# Altium schematic sheet Power.SchDoc(Power)
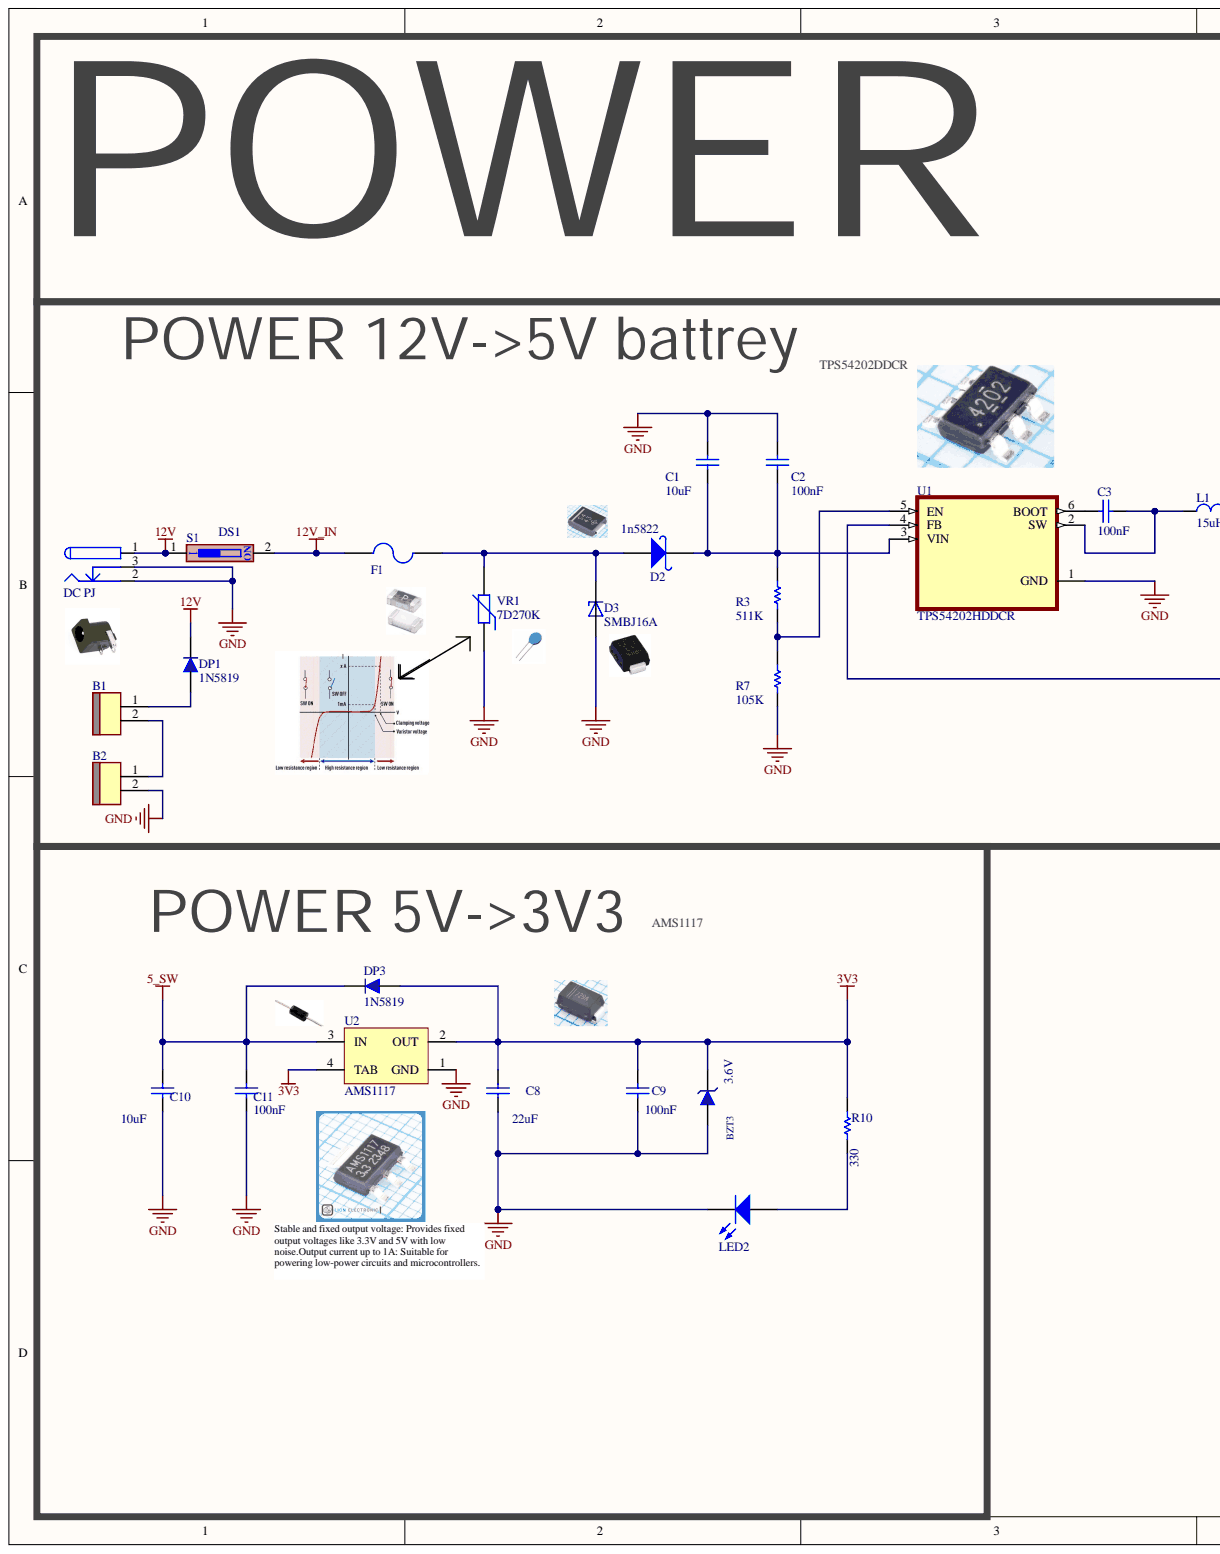
[diagram p0: Power.SchDoc, left]
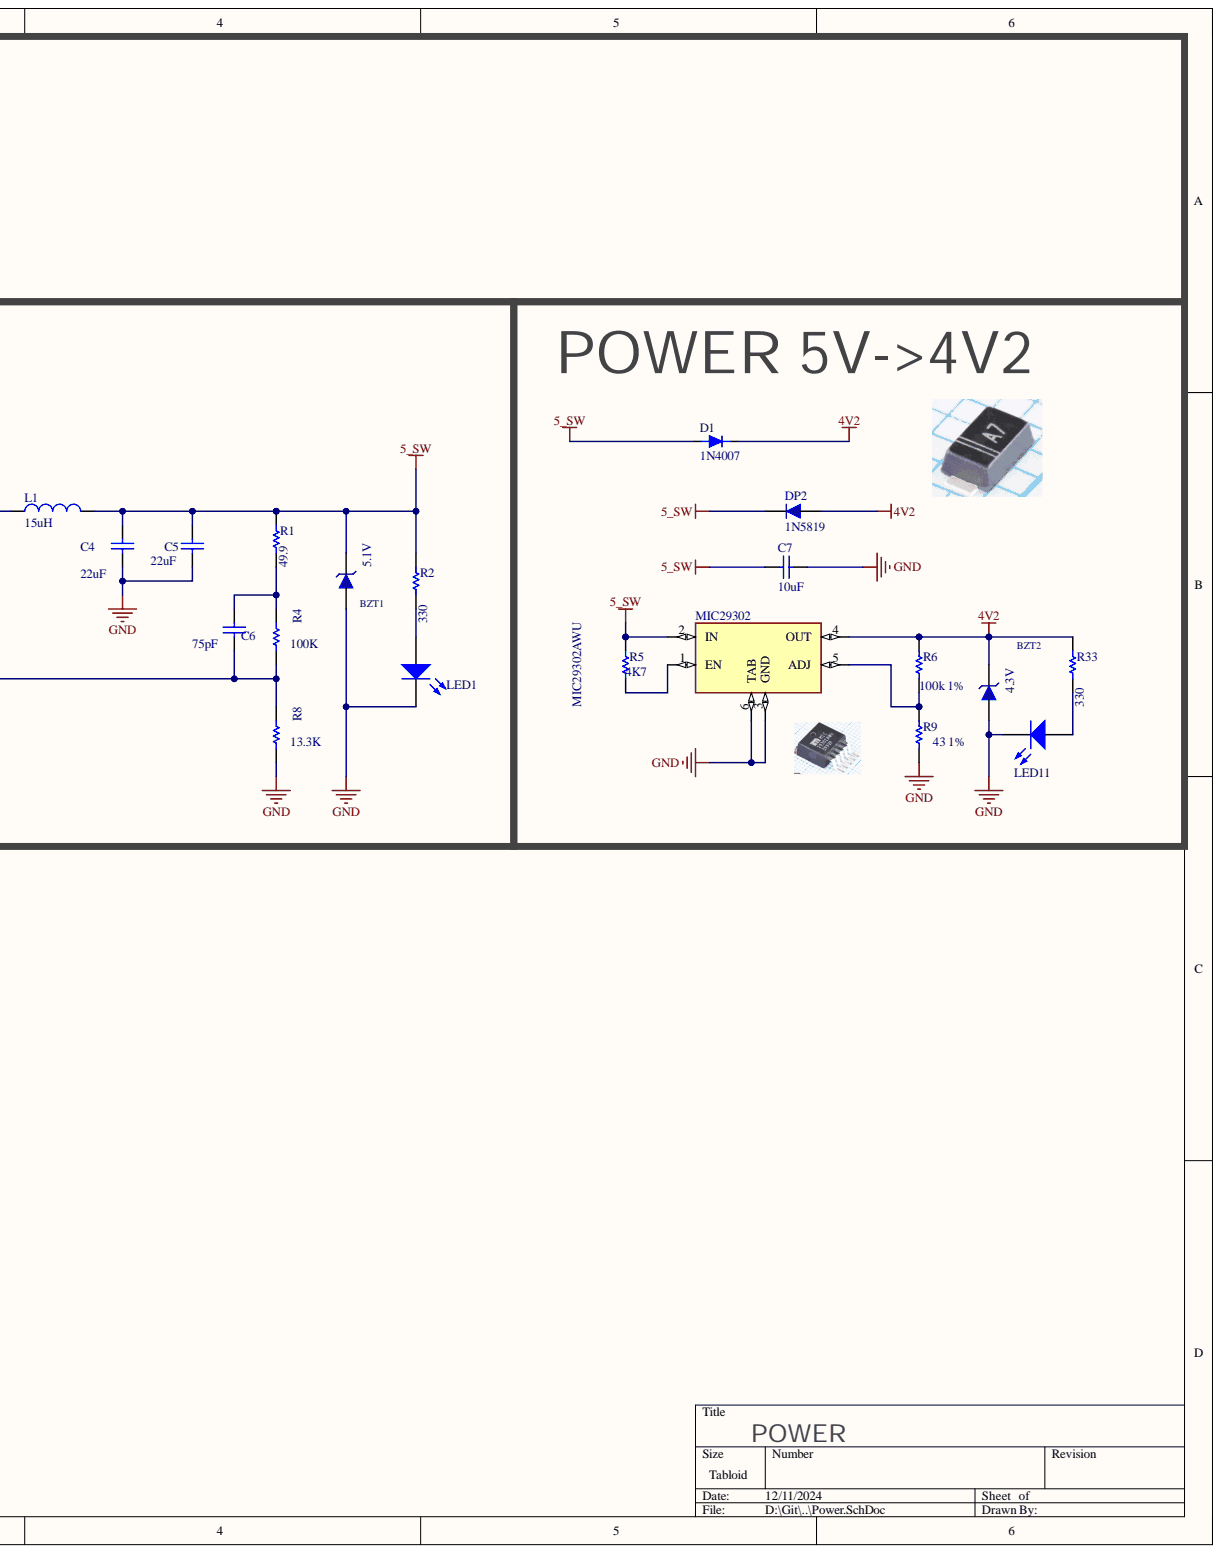
[diagram p1: Power.SchDoc, right]

## Parts
B1  JST BxB-PH-K 2Pin  [JST B2B-PH-K]  (value not drawn)
B2  JST BxB-PH-K 2Pin  [JST B2B-PH-K]  (value not drawn)
BZT1  5.1V  [SOD123]
BZT2  4.3V  [SOD123]
BZT3  3.6V  [SOD123]
C1  10uF  [MY_CAP_SMD_0603]
C2  100nF  [MY_CAP_SMD_0603]
C3  100nF  [MY_CAP_SMD_0603]
C4  22uF  [MY_CAP_SMD_0603]
C5  22uF  [MY_CAP_SMD_0603]
C6  75pF  [MY_CAP_SMD_0603]
C7  10uF  [MY_CAP_SMD_0603]
C8  22uF  [MY_CAP_SMD_0603]
C9  100nF  [MY_CAP_SMD_0603]
C10  10uF  [MY_CAP_SMD_0603]
C11  100nF  [MY_CAP_SMD_0603]
D1  1N4007  [DO-214AC]
D2  1n5822  [DO-214AB(SMC)]
D3  SMBJ16A  [DIOM4336X265N]
DC_PJ  DC Power Jack  [DC Power Jack 1]  (value not drawn)
DP1  1N5819  [1n58XX DO-201AD]
DP2  1N5819  [430X270D]
DP3  1N5819  [430X270D]
F1  F=3.0 A  [1206 FUSE]  (value not drawn)
L1  15uH  [INDUCTOR_SMD_3A]
LED1  LED SMD 0603 BLUE  [LED_0603_RED]  (value not drawn)
LED2  LED SMD 0603 BLUE  [LED_0603_RED]  (value not drawn)
LED11  LED SMD 0603 BLUE  [LED_0603_RED]  (value not drawn)
MIC29302  MIC29302AWU  [TO263-5]
R1  49.9  [RESC3413X04N]
R2  330  [RESC3413X04N]
R3  511K  [RESC3413X04N]
R4  100K  [RESC3413X04N]
R5  4K7  [RESC3413X04N]
R6  100k 1%  [RESC3413X04N]
R7  105K  [RESC3413X04N]
R8  13.3K  [RESC3413X04N]
R9  43 1%  [RESC3413X04N]
R10  330  [RESC3413X04N]
R33  330  [RESC3413X04N]
S1  DS1  [DIP Switch x1]
U1  TPS54202HDDCR  [SOT95P280X110-6N]
U2  AMS1117  [SOT223_M]
VR1  7D270K  [07D XXX]

## Nets
3V3: BZT3.2 C8.1 C9.1 DP3.1 R10.2 U2.2(OUT) U2.4(TAB)
4V2: BZT2.2 D1.2 DP2.1 MIC29302.4(OUT) R6.2 R33.2
5_SW: BZT1.2 C4.1 C5.1 C7.1 C10.1 C11.1 D1.1 DP2.2 DP3.2 L1.2 MIC29302.2(IN) R1.2 R2.2 R5.2 U2.3(IN)
12V: DC_PJ.1 DP1.2 S1.1
12V_IN: F1.1 S1.2
GND: B2.2 BZT1.1 BZT2.1 BZT3.1 C1.1 C2.1 C4.2 C5.2 C7.2 C8.2 C9.2 C10.2 C11.2 D3.A DC_PJ.2 DC_PJ.3 LED1.2 LED2.2 LED11.2 MIC29302.3(GND) MIC29302.6(TAB) R7.2 R8.2 R9.1 U1.1(GND) U2.1(GND) VR1.1
NetB1_1: B1.1 DP1.1
NetB1_2: B1.2 B2.1
NetC1_2: C1.2 C2.2 D2.2 R3.1 U1.3(VIN)
NetC3_1: C3.1 U1.6(BOOT)
NetC3_2: C3.2 L1.1 U1.2(SW)
NetC6_1: C6.1 R1.1 R4.1
NetC6_2: C6.2 R4.2 R8.1 U1.4(FB)
NetD2_1: D2.1 D3.C F1.2 VR1.2
NetLED1_1: LED1.1 R2.1
NetLED2_1: LED2.1 R10.1
NetLED11_1: LED11.1 R33.1
NetMIC29302_1: MIC29302.1(EN) R5.1
NetMIC29302_5: MIC29302.5(ADJ) R6.1 R9.2
NetR3_2: R3.2 R7.1 U1.5(EN)

## Library data of the parts
B1 B2 = JST BxB-PH-K 2Pin: Connector Header Through Hole 0.079" (2.00mm); PartNumber: B2B-PH-K-S(LF)(SN); Component Kind: Standard; Library Name: Conn Terminal.IntLib
BZT1-BZT3 = ZENER GENERAL DIODE: DIODE ZENER GENERAL; VALUE: 12V; Component Kind: Standard; ComponentLink1Description: Manufacturer Link; ComponentLink2Description: Datasheet; Library Name: Altium.IntLib; PackageDescription: DIODE GEN ZENER; PackageReference: DO 35; PackageVersion: 2017-1-12; Published: 2017-1-12; Publisher: Abbas Basereh
C1-C11 = CAP SMD_0603: Component Kind: Standard; Description: CAP SMD_0603; Library Name: AMINLIB.IntLib
D1 = 1N4007-1: 1N4007 smd diode; Component Kind: Standard; Library Name: Sajad_Altium_Library.IntLib
D2 = 1N5822 SMD: Schottky Rectifiers; Manufacturer: ON Semiconductor/Fairchild; Part. No: SS34; Designer: Abbas Basereh; Component Kind: Standard; Data: 16-12-2016; Library Name: Altium.IntLib; Link: https://www.aliexpress.com/item/Free-shipping-100pcs-1n5822-sma-smd-do-214ac-Schottky-diode-ss34/32337482329.html?spm=2114.01010208.3.1.zLZDsP&ws_ab_test=searchweb0_0,searchweb201602_4_10065_10068_10084_10083_10080_10082_10081_10060_10061_10062_10056_10055_10054_10059_10099_10078_10079_427_10073_10103_10102_10096_10052_10050_10051,searchweb201603_4&btsid=6e50dc92-ac69-45bc-a19e-209af19ce602
D3 = SMBJ16A: 600W, 18.8V, 5%, Unidirectional, TVS; MF: Taiwan Semiconductor; Availability: In Stock; Check_prices: https://www.snapeda.com/parts/SMBJ16A/Taiwan+Semiconductor/view-part/?ref=eda; Component Kind: Standard; Library Name: SMBJ16A.IntLib; MP: SMBJ16A; Package: SMD-2-2 Avago; Price: None; Purchase-URL: https://pricing.snapeda.com/search/part/SMBJ16A/?ref=eda; SnapEDA_Link: https://www.snapeda.com/parts/SMBJ16A/Taiwan+Semiconductor/view-part/?ref=snap
DC_PJ = DC Power Jack: DC Power Jack. Center Pin 2.5mm. Diameter: 5.7mm; Company: Personal; Designer: Ali Hafizi; Project Name: Laser; Adding Date: 10/21/2009; Component Kind: Standard; Library Name: Altium.IntLib
DP1-DP3 = 1N5819: Schottkey Rectifiers; Designer: Abbas Basereh; Component Kind: Standard; Data: 16-12-2016; Library Name: Altium.IntLib
F1 = Fuse SMD1206: SMD Fuses 1206; Designer: Abbas Basereh; Component Kind: Standard; Data: 16-12-2016; Desc.: SMD Fuses 1206; Library Name: Altium.IntLib
L1 = INDUCTOR_SMD_3A: Component Kind: Standard; Description: INDUCTOR_SMD_3A; Library Name: AMINLIB.IntLib
MIC29302 = MIC29302AWU: Linear Voltage Regulator IC Positive Adjustable 1 Output 3A TO-263-5; MF: Microchip; Check_prices: https://www.snapeda.com/parts/MIC29302AWU/Microchip/view-part/?ref=eda; Component Kind: Standard; Library Name: MIC29302AWU.IntLib; MP: MIC29302AWU; Package: TO-263-5 Microchip; SnapEDA_Link: https://www.snapeda.com/parts/MIC29302AWU/Microchip/view-part/?ref=snap
R1-R10 R33 = RES 0805 0.125W: RES 0805; Desc.: RES SMD 0805; Designer: Abbas Basereh; Component Kind: Standard; Data: 16-12-2016; Library Name: Altium.IntLib
S1 = DIP Switch 1: 1 BIT DIP Switch; Component Kind: Standard; Library Name: Altium.IntLib
U1 = TPS54202HDDCR: 4.5V to 28V Input, 2A Output, Synchronous Step-Down Voltage Converter 6-SOT-23-THIN -40 to 125; MF: Texas Instruments; Availability: Good; Check_prices: https://www.snapeda.com/parts/TPS54202HDDCR/Texas%20Instruments/view-part/573706/?ref=eda; Component Kind: Standard; Library Name: TPS54202HDDCR.IntLib; MP: TPS54202HDDCR; Package: SOT-23-THN-6 Texas Instruments; Price: None; Purchase-URL: https://pricing.snapeda.com/search/part/TPS54202HDDCR/?ref=eda; SnapEDA_Link: https://www.snapeda.com/parts/TPS54202HDDCR/Texas%20Instruments/view-part/573706/?ref=snap
U2 = AMS1117-x.x: x.x Volt, 800mA Low-Dropout Linear Regulator, 4-pin SOT-223; Designer: Ali Hafizi; Component Kind: Standard; Data: 16-12-2016; Library Name: Altium.IntLib
VR1 = VARISTOR (VDR): VARISTORS; Manufacturer: china-canada-philipina; Designer: Abbas Basereh; Models: MOV-VDR-COV; VALUE: xxDxxx; Component Kind: Standard; Data: 2017-1-5; Library Name: Altium.IntLib; Link: digikey.com; PUBLISHER: ABBAS BASEREH
LED1 LED2 LED11 = LED SMD 0603 BLUE: Component Kind: Standard; Library Name: Led.IntLib
